# Altium schematic sheet MSP430.SchDoc
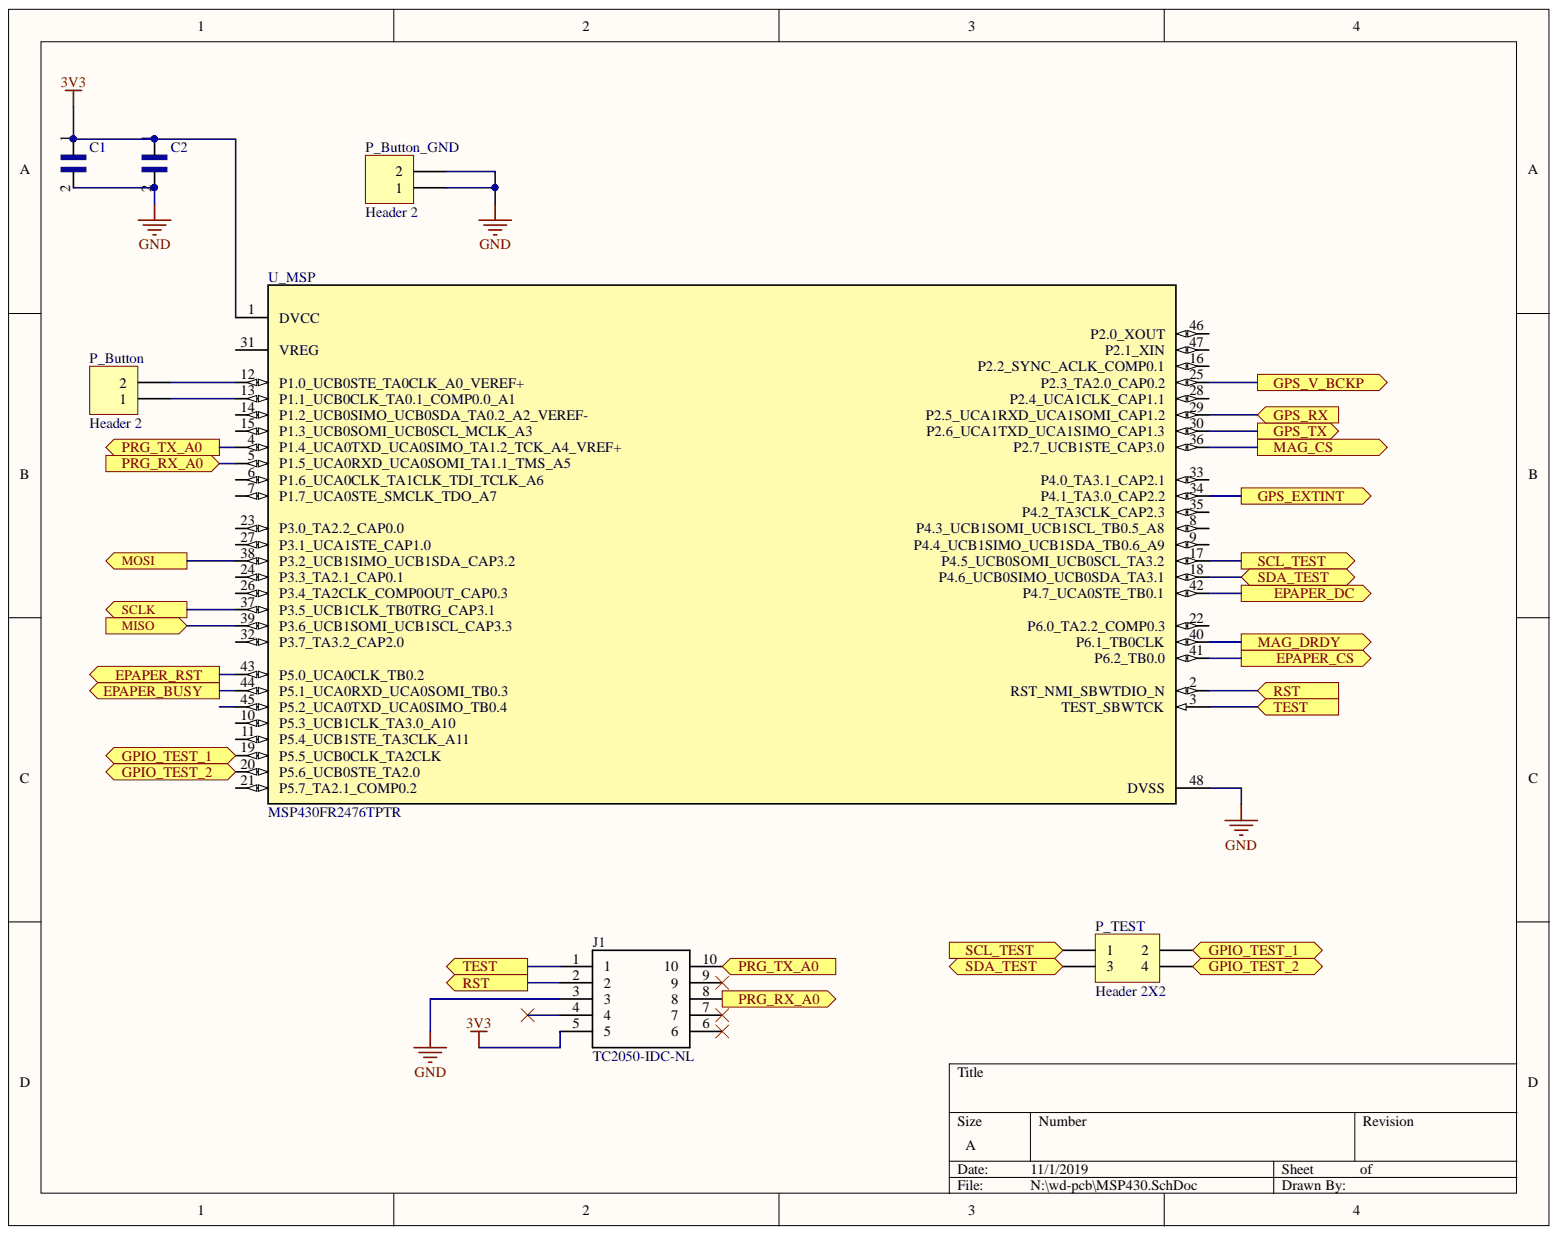
[diagram p0: MSP430.SchDoc]

## Parts
C1  TACL106K010XTA  [*CAPC1608X90]  (value not drawn)
C2  GMK107BJ104KAHT  [*CAPC1608X90N]  (value not drawn)
J1  TC2050-IDC-NL  [*TAG-CONNECT_TC2050-IDC-NL]
P_Button  Header 2  [HDR1X2]
P_Button_GND  Header 2  [HDR1X2]
P_TEST  Header 2X2  [HDR2X2]
U_MSP  MSP430FR2476TPTR  [PT0048A_N]

## Ports
EPAPER_BUSY, EPAPER_CS, EPAPER_DC, EPAPER_RST, GPIO_TEST_1, GPIO_TEST_2, GPS_EXTINT, GPS_RX, GPS_TX, GPS_V_BCKP, MAG_CS, MAG_DRDY, MISO, MOSI, PRG_RX_A0, PRG_TX_A0, RST, SCL_TEST, SCLK, SDA_TEST, TEST

## Nets
3V3: C1.1 C2.1 J1.5 U_MSP.1(DVCC)
GND: C1.2 C2.2 J1.3 P_Button_GND.1 P_Button_GND.2 U_MSP.48(DVSS)
EPAPER_BUSY: U_MSP.44(P5.1_UCA0RXD_UCA0SOMI_TB0.3) ; port EPAPER_BUSY
EPAPER_CS: U_MSP.41(P6.2_TB0.0) ; port EPAPER_CS
EPAPER_DC: U_MSP.42(P4.7_UCA0STE_TB0.1) ; port EPAPER_DC
EPAPER_RST: U_MSP.43(P5.0_UCA0CLK_TB0.2) ; port EPAPER_RST
GPIO_TEST_1: P_TEST.2 U_MSP.19(P5.5_UCB0CLK_TA2CLK) ; port GPIO_TEST_1
GPIO_TEST_2: P_TEST.4 U_MSP.20(P5.6_UCB0STE_TA2.0) ; port GPIO_TEST_2
GPS_EXTINT: U_MSP.34(P4.1_TA3.0_CAP2.2) ; port GPS_EXTINT
GPS_RX: U_MSP.29(P2.5_UCA1RXD_UCA1SOMI_CAP1.2) ; port GPS_RX
GPS_TX: U_MSP.30(P2.6_UCA1TXD_UCA1SIMO_CAP1.3) ; port GPS_TX
GPS_V_BCKP: U_MSP.25(P2.3_TA2.0_CAP0.2) ; port GPS_V_BCKP
MAG_CS: U_MSP.36(P2.7_UCB1STE_CAP3.0) ; port MAG_CS
MAG_DRDY: U_MSP.40(P6.1_TB0CLK) ; port MAG_DRDY
MISO: U_MSP.39(P3.6_UCB1SOMI_UCB1SCL_CAP3.3) ; port MISO
MOSI: U_MSP.38(P3.2_UCB1SIMO_UCB1SDA_CAP3.2) ; port MOSI
PRG_RX_A0: J1.8 U_MSP.5(P1.5_UCA0RXD_UCA0SOMI_TA1.1_TMS_A5) ; port PRG_RX_A0
PRG_TX_A0: J1.10 U_MSP.4(P1.4_UCA0TXD_UCA0SIMO_TA1.2_TCK_A4_VREF+) ; port PRG_TX_A0
RST: J1.2 U_MSP.2(RST_NMI_SBWTDIO_N) ; port RST
SCL_TEST: P_TEST.1 U_MSP.17(P4.5_UCB0SOMI_UCB0SCL_TA3.2) ; port SCL_TEST
SCLK: U_MSP.37(P3.5_UCB1CLK_TB0TRG_CAP3.1) ; port SCLK
SDA_TEST: P_TEST.3 U_MSP.18(P4.6_UCB0SIMO_UCB0SDA_TA3.1) ; port SDA_TEST
TEST: J1.1 U_MSP.3(TEST_SBWTCK) ; port TEST
NetP_Button_1: P_Button.1 U_MSP.13(P1.1_UCB0CLK_TA0.1_COMP0.0_A1)
NetP_Button_2: P_Button.2 U_MSP.12(P1.0_UCB0STE_TA0CLK_A0_VEREF+)

Not connected: J1 4 6 7 9; U_MSP 6(P1.6_UCA0CLK_TA1CLK_TDI_TCLK_A6) 7(P1.7_UCA0STE_SMCLK_TDO_A7) 8(P4.3_UCB1SOMI_UCB1SCL_TB0.5_A8) 9(P4.4_UCB1SIMO_UCB1SDA_TB0.6_A9) 10(P5.3_UCB1CLK_TA3.0_A10) 11(P5.4_UCB1STE_TA3CLK_A11) 14(P1.2_UCB0SIMO_UCB0SDA_TA0.2_A2_VEREF-) 15(P1.3_UCB0SOMI_UCB0SCL_MCLK_A3) 16(P2.2_SYNC_ACLK_COMP0.1) 21(P5.7_TA2.1_COMP0.2) 22(P6.0_TA2.2_COMP0.3) 23(P3.0_TA2.2_CAP0.0) 24(P3.3_TA2.1_CAP0.1) 26(P3.4_TA2CLK_COMP0OUT_CAP0.3) 27(P3.1_UCA1STE_CAP1.0) 28(P2.4_UCA1CLK_CAP1.1) 31(VREG) 32(P3.7_TA3.2_CAP2.0) 33(P4.0_TA3.1_CAP2.1) 35(P4.2_TA3CLK_CAP2.3) 45(P5.2_UCA0TXD_UCA0SIMO_TB0.4) 46(P2.0_XOUT) 47(P2.1_XIN)

## Library data of the parts
C1 = TACL106K010XTA: Cap Tant Solid 10uF 10V L CASE 10% _1.6 X 0.85 X 0.85mm_ SMD 1608-10 7.5 Ohm 125Â°C Plastic T/R; MF: AVX; Component Kind: Standard; Digi-Key_Part_Number: 478-8775-1-ND; Digi-Key_Purchase_URL: https://www.digikey.com/product-detail/en/avx-corporation/TACL106K010XTA/478-8775-1-ND/4556169?utm_source=snapeda&utm_medium=aggregator&utm_campaign=symbol; Library Name: TACL106K010XTA.IntLib; MP: TACL106K010XTA; Package: 0603 AVX; SnapEDA_Link: https://www.snapeda.com/parts/TACL106K010XTA/AVX%20/%20Kyocera/view-part/?ref=snap
C2 = GMK107BJ104KAHT: CAP , MLCC , 0603, 35V , X5R, 0.1uF10% , T&R; MF: Taiyo Yuden; Component Kind: Standard; Digi-Key_Part_Number: 587-3357-1-ND; Digi-Key_Purchase_URL: https://www.digikey.com/product-detail/en/taiyo-yuden/GMK107BJ104KAHT/587-3357-1-ND/4157244?utm_source=snapeda&utm_medium=aggregator&utm_campaign=symbol; Library Name: GMK107BJ104KAHT.IntLib; MP: GMK107BJ104KAHT; Package: 1608 Taiyo Yuden; SnapEDA_Link: https://www.snapeda.com/parts/GMK107BJ104KAHT/Taiyo%20Yuden/view-part/?ref=snap
J1 = TC2050-IDC-NL: CBL PLUG-OF-NAILS 10-PIN; MF: Tag-Connect LLC; Component Kind: Standard; Digi-Key_Part_Number: TC2050-IDC-NL-ND; Digi-Key_Purchase_URL: https://www.digikey.com/product-detail/en/tag-connect-llc/TC2050-IDC-NL/TC2050-IDC-NL-ND/2605367?utm_source=snapeda&utm_medium=aggregator&utm_campaign=symbol; Library Name: TC2050-IDC-NL.SchLib; MP: TC2050-IDC-NL; Package: None; SnapEDA_Link: https://www.snapeda.com/parts/TC2050-IDC-NL/Tag-Connect%20LLC/view-part/?ref=snap
P_Button P_Button_GND = Header 2: Header, 2-Pin; Component Kind: Standard; LatestRevisionDate: 17-Jul-2002; LatestRevisionNote: Re-released for DXP Platform.; Library Name: Miscellaneous Connectors.IntLib; Signal Integrity: Connector; Publisher: Altium Limited
P_TEST = Header 2X2: Header, 2-Pin, Dual row; Component Kind: Standard; LatestRevisionDate: 17-Jul-2002; LatestRevisionNote: Re-released for DXP Platform.; Library Name: Miscellaneous Connectors.IntLib; Signal Integrity: Connector; Publisher: Altium Limited
U_MSP = MSP430FR2476TPTR: Imported; Manufacturer: Texas Instruments; Component Kind: Standard; Library Name: MSP430FR2476TPTR.IntLib; PartNumber: MSP430FR2476TPTR; RefDes: RefDes; TYPE: TYPE; Value: Value
